# Altium schematic sheet AUX_Stufe.SchDoc
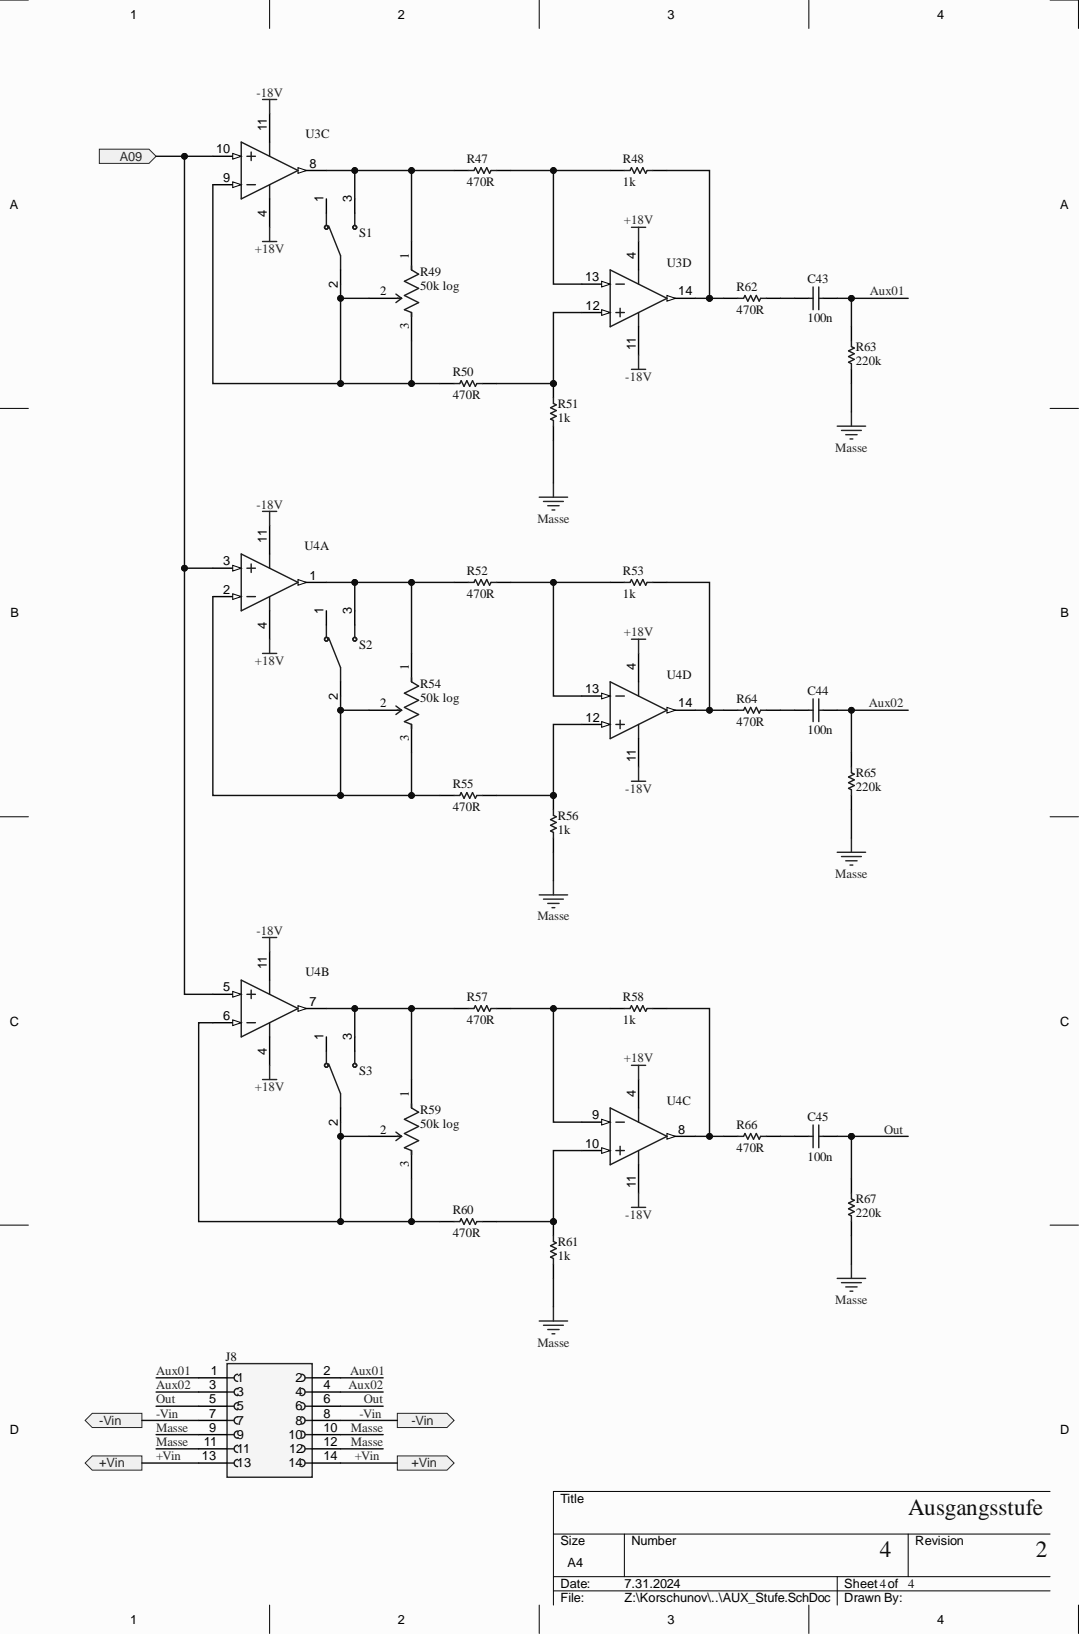
[diagram p0: AUX_Stufe.SchDoc]

## Parts
C43  100n  [0805]
C44  100n  [0805]
C45  100n  [0805]
J8  Connector x14  [Connector x14]  (value not drawn)
R47  470R  [0805]
R48  1k  [0805]
R49  50k log  [Connector x3]
R50  470R  [0805]
R51  1k  [0805]
R52  470R  [0805]
R53  1k  [0805]
R54  50k log  [Connector x3]
R55  470R  [0805]
R56  1k  [0805]
R57  470R  [0805]
R58  1k  [0805]
R59  50k log  [Connector x3]
R60  470R  [0805]
R61  1k  [0805]
R62  470R  [0805]
R63  220k  [0805]
R64  470R  [0805]
R65  220k  [0805]
R66  470R  [0805]
R67  220k  [0805]
S1  Schalter Buchsenleiste  [Connector x3]  (value not drawn)
S2  Schalter Buchsenleiste  [Connector x3]  (value not drawn)
S3  Schalter Buchsenleiste  [Connector x3]  (value not drawn)
U3  OPA4134UA  [DIP14 IC Socket]  (value not drawn)  (parts C,D)
U4  OPA4134UA  [DIP14 IC Socket]  (value not drawn)  (parts A,B,C,D)

## Ports
+Vin, -Vin, A09

## Nets
+18V: U3.4 U4.4
-18V: U3.11 U4.11
+Vin: J8.13 J8.14 ; port +Vin
-Vin: J8.7 J8.8 ; port -Vin
A09: U3.10 U4.3 U4.5 ; port A09
Aux01: C43.2 J8.1 J8.2 R63.2
Aux02: C44.2 J8.3 J8.4 R65.2
Masse: J8.9 J8.10 J8.11 J8.12 R51.1 R56.1 R61.1 R63.1 R65.1 R67.1
Out: C45.2 J8.5 J8.6 R67.2
NetC43_1: C43.1 R62.2
NetC44_1: C44.1 R64.2
NetC45_1: C45.1 R66.2
NetR47_1: R47.1 R49.1 S1.3 U3.8
NetR47_2: R47.2 R48.1 U3.13
NetR48_2: R48.2 R62.1 U3.14
NetR49_2: R49.2 R49.3 R50.1 S1.2 U3.9
NetR50_2: R50.2 R51.2 U3.12
NetR52_1: R52.1 R54.1 S2.3 U4.1
NetR52_2: R52.2 R53.1 U4.13
NetR53_2: R53.2 R64.1 U4.14
NetR54_2: R54.2 R54.3 R55.1 S2.2 U4.2
NetR55_2: R55.2 R56.2 U4.12
NetR57_1: R57.1 R59.1 S3.3 U4.7
NetR57_2: R57.2 R58.1 U4.9
NetR58_2: R58.2 R66.1 U4.8
NetR59_2: R59.2 R59.3 R60.1 S3.2 U4.6
NetR60_2: R60.2 R61.2 U4.10

Not connected: S1 1; S2 1; S3 1

## Library data of the parts
C43-C45 = 0805 Kondensator: Component Kind: Standard; Description: 0805 Kondensator; Value: 100n; Library Name: Bauteile.SchLib
J8 = Connector x14: 14 Position Header Connector Through Hole; MF: Sullins Connector; MP: SFH11-PBPC-D07-ST-BK; Availability: Warning; Check_prices: https://www.snapeda.com/parts/SFH11-PBPC-D07-ST-BK/Sullins%20Connector%20Solutions/view-part/851415/?ref=eda; Component Kind: Standard; Package: None; Price: None; Purchase-URL: https://pricing.snapeda.com/search/part/SFH11-PBPC-D07-ST-BK/?ref=eda; SnapEDA_Link: https://www.snapeda.com/parts/SFH11-PBPC-D07-ST-BK/Sullins%20Connector%20Solutions/view-part/851415/?ref=snap; Library Name: Bauteile.SchLib
R47 R48 R50-R53 R55-R58 R60-R67 = 0805 Widerstand: Component Kind: Standard; Description: 0805 Widerstand; Library Name: Bauteile.SchLib
  R47 R50 R52 R55 R57 R60 R62 R64 R66: Value: 470R
  R48 R51 R53 R56 R58 R61: Value: 1k
  R63 R65 R67: Value: 220k
R49 R54 R59 = Potentiometer Buchsenleiste: Potentiometer; Component Kind: Standard; Value: 50k log; Library Name: Bauteile.SchLib
S1-S3 = Schalter Buchsenleiste: Toggle Switch SPDT Through Hole; MP: 100SP1T1B4M2QE; Availability: Unavailable; Component Kind: Standard; Package: None; Price: None; Library Name: Bauteile.SchLib
U3 U4 = SOIC OPA4134UA: SoundPlus(TM) High Performance Audio Operational Amplifier, 5 to 36 V, -55 to 125 degC, 14-pin SOIC (D14), Green (RoHS & no Sb/Br); Manufacturer: Texas Instruments; GBW(Typ)(MHz): 8; Iq per channel(Max)(mA): 5; Number of Channels: 4; Vmax(V): 36; Code_JEDEC: MS-012; Component Kind: Standard; ComponentLink1Description: Manufacturer URL; ComponentLink1URL: http://www.ti.com/; ComponentLink2Description: Datasheet; ComponentLink2URL: http://www.ti.com/general/docs/lit/getliterature.tsp?genericPartNumber=OPA4134&fileType=pdf; ComponentLink3Description: Package Specification; ComponentLink3URL: http://www.ti.com/lit/ml/mpds177g/mpds177g.pdf; DatasheetVersion: SBOS058; Eco-Plan: Green (RoHS & no Sb/Br); Mounting Technology: Surface Mount; PackageDescription: 14-Pin Plastic Small Outline, Body 8.65 x 3.9 mm, Pitch 1.27 mm; PackageReference: D0014A; PackageVersion: 4040047-5/M, 06/2011; PartNumber: OPA4134UA; SubFamily: Audio Operational Amplifier; Vmin(V): 5; Vn at Flatband(Typ)(nV/rtHz): 8; Library Name: Bauteile.SchLib
